# Altium schematic sheet Driver Morpheus PONTE H.SchDoc("Driver Morpheus PONTE H")
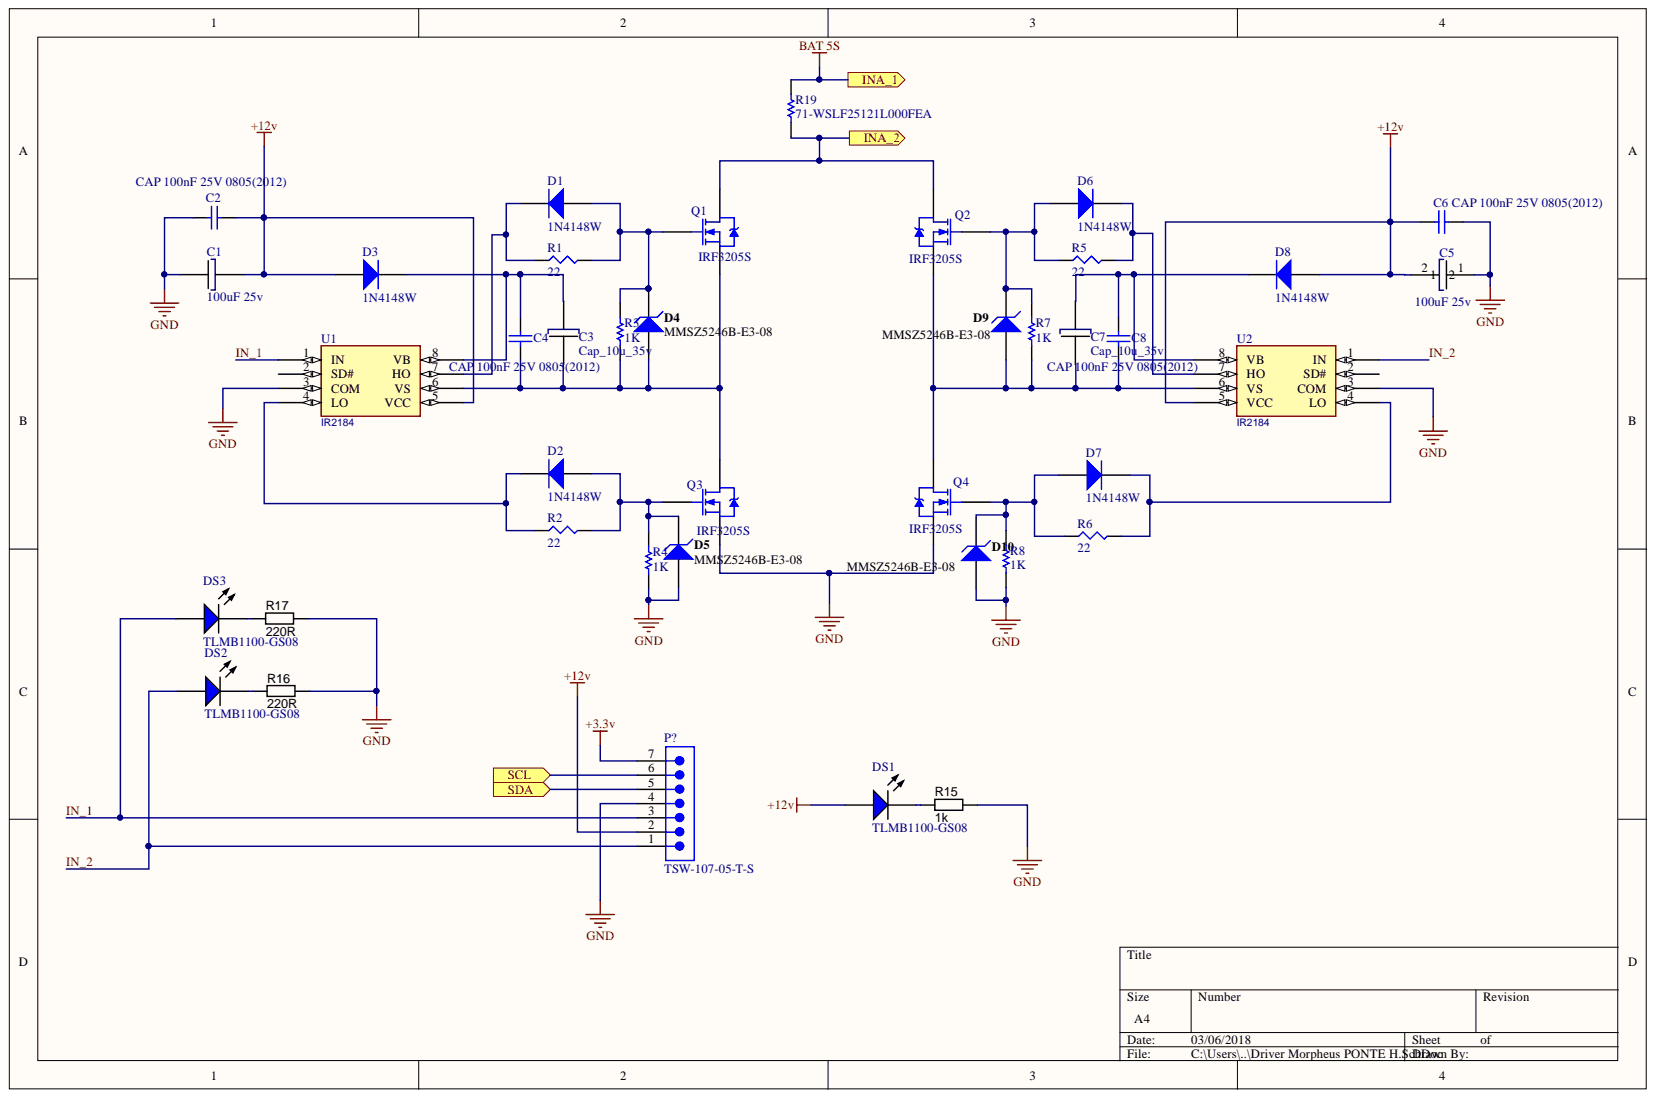
[diagram p0: Driver Morpheus PONTE H.SchDoc]

## Parts
C1  100uF 25v  [CAPAE9083X70M]
C2  CAP 100nF 25V 0805(2012)  value 100nF  [CAPC0805(2012)145_M]
C3  Cap_10u_35v  [CAP POL 2917/7343]
C4  CAP 100nF 25V 0805(2012)  value 100nF  [CAPC0805(2012)145_M]
C5  100uF 25v  [CAPAE9083X70M]
C6  CAP 100nF 25V 0805(2012)  value 100nF  [CAPC0805(2012)145_M]
C7  Cap_10u_35v  [CAP POL 2917/7343]
C8  CAP 100nF 25V 0805(2012)  value 100nF  [CAPC0805(2012)145_M]
D1  1N4148W  [JSCJ-SOD-123-2_V]
D2  1N4148W  [JSCJ-SOD-123-2_V]
D3  1N4148W  [JSCJ-SOD-123-2_V]
D4  MMSZ5246B-E3-08  [VISH-SOD-123-2_V]
D5  MMSZ5246B-E3-08  [VISH-SOD-123-2_V]
D6  1N4148W  [JSCJ-SOD-123-2_V]
D7  1N4148W  [JSCJ-SOD-123-2_V]
D8  1N4148W  [JSCJ-SOD-123-2_V]
D9  MMSZ5246B-E3-08  [VISH-SOD-123-2_V]
D10  MMSZ5246B-E3-08  [VISH-SOD-123-2_V]
DS1  TLMB1100-GS08  [VISH-TLMX1100-2_V]
DS2  TLMB1100-GS08  [VISH-TLMX1100-2_V]
DS3  TLMB1100-GS08  [VISH-TLMX1100-2_V]
P?  TSW-107-05-T-S  [SMTC-TSW-107-05-X-S]
Q1  IRF3205S  [D2PAK_N]
Q2  IRF3205S  [D2PAK_N]
Q3  IRF3205S  [D2PAK_N]
Q4  IRF3205S  [D2PAK_N]
R1  22  [SMD-0603-RES]
R2  22  [SMD-0603-RES]
R3  value 1K  [RES-0402]  (hidden comment: RC0402JR-071KL)
R4  value 1K  [RES-0402]  (hidden comment: RC0402JR-071KL)
R5  22  [SMD-0603-RES]
R6  22  [SMD-0603-RES]
R7  value 1K  [RES-0402]  (hidden comment: RC0402JR-071KL)
R8  value 1K  [RES-0402]  (hidden comment: RC0402JR-071KL)
R15  value 1k  [RESC1608X55N]  (hidden comment: Thick Film)
R16  value 220R  [RESC1608X55N]  (hidden comment: Thick Film)
R17  value 220R  [RESC1608X55N]  (hidden comment: Thick Film)
R19  71-WSLF25121L000FEA  [RESC6432X89X76ML25T25]
U1  IR2184
U2  IR2184

## Ports
INA_1, INA_2, SCL, SDA

## Nets
+3.3v: P?.7
+12v: C1.2 C2.2 C5.2 C6.2 D3.1 D8.1 DS1.1 P?.2 U1.5(VCC) U2.5(VCC)
GND: C1.1 C2.1 C5.1 C6.1 D5.1 D10.1 P?.4 Q3.3 Q4.3 R4.1 R8.1 R15.2 R16.2 R17.2 U1.3(COM) U2.3(COM)
BAT 5S: R19.2 ; port INA_1
IN_1: DS3.1 P?.3 U1.1(IN)
IN_2: DS2.1 P?.1 U2.1(IN)
INA_2: Q1.2 Q2.2 R19.1 ; port INA_2
SCL: P?.6 ; port SCL
SDA: P?.5 ; port SDA
NetC3_1: C3.1 C4.1 D4.1 Q1.3 Q3.2 R3.1 U1.6(VS)
NetC3_2: C3.2 C4.2 D3.2 U1.8(VB)
NetC7_1: C7.1 C8.1 D9.1 Q2.3 Q4.2 R7.1 U2.6(VS)
NetC7_2: C7.2 C8.2 D8.2 U2.8(VB)
NetD1_1: D1.1 D4.2 Q1.1 R1.2 R3.2
NetD1_2: D1.2 R1.1 U1.7(HO)
NetD2_1: D2.1 D5.2 Q3.1 R2.2 R4.2
NetD2_2: D2.2 R2.1 U1.4(LO)
NetD6_1: D6.1 D9.2 Q2.1 R5.1 R7.2
NetD6_2: D6.2 R5.2 U2.7(HO)
NetD7_1: D7.1 D10.2 Q4.1 R6.1 R8.2
NetD7_2: D7.2 R6.2 U2.4(LO)
NetDS1_2: DS1.2 R15.1
NetDS2_2: DS2.2 R16.1
NetDS3_2: DS3.2 R17.1

Not connected: U1 2(SD#); U2 2(SD#)

## Library data of the parts
C1 = Cap_10u_35v: Component Kind: Standard; Library Name: LibSanhaço2017.SchLib
C2 C4 C6 C8 = CMP-1036-04408-1: CAP 100nF 25V ±5% 0805 (2012 Metric) Thickness 1.45mm SMD; Tolerance: Â±5%; Case-Metric: 2012; Case-EIA: 0805; Technology: SMT; Rated Voltage: 25 V; Max Thickness: 1.45 mm; Library Name: Altium Content Vault; Component Kind: Standard
C5 = Cap_100u_25v: Cap Tântalo; Component Kind: Standard; Library Name: LibSanhaço2017.SchLib
D1-D3 D6-D8 = CMP-0914-00001-1: Fast Switching Diode, 75 V, 150 mA, 2-Pin 2.7 x 1.6 x 1.25 mm SMD, Tape and Reel; Manufacturer: Changjiang Electronics; VR (V): 75; VF (V): 1.25; TSTG (degC): -55 to 150; Trr (ns): 4; PD (mW): 350; IR (uA): 1; IO (mA): 150; PartNumber: 1N4148W; Packing: Tape and Reel; PackageVersion: Rev. A; PackageReference: SOD-123-2; PackageDescription: 2-Pin SMD, Body 2.7 x 1.6 mm, Height 1.25 mm; Mounting Technology: Surface Mount; Library Name: Altium Content Vault; DatasheetVersion: Rev. A; ComponentLink3URL: http://www.cj-elec.com/txUpfile/201412112231195145.pdf; ComponentLink3Description: Package Specification; ComponentLink2URL: http://www.cj-elec.com/txUpfile/20121613352740313.pdf; ComponentLink2Description: Datasheet; ComponentLink1URL: http://www.cj-elec.com/; ComponentLink1Description: Manufacturer URL; Component Kind: Standard
D4 D5 D9 D10 = CMP-0931-00032-1: Small Signal Zener Diode, 16 V +/- 5%, -55 to 155 degC, 2-Pin SOD-123, RoHS, Tape and Reel; Manufacturer: Vishay Semiconductor; Zener Voltage (NOM.) (V): 16; Zener Impedance (IZT1) (Max.) (Ohm): 17; Tolerance: +/- 5%; Test Current (IZT1) (mA): 7.8; Power Dissipation (mW): 500; Int. Construction: Single; RoHS: TRUE; PartNumber: MMSZ5246B-E3-08; Packing: Tape and Reel; PackageVersion: Rev. 1.9; PackageReference: SOD-123-2; PackageDescription: 2-Pin SMD, Body 2.7 x 1.55 mm, Height 1.35 mm; Operating Temperature: -55 to 150 degC; Mounting Technology: Surface Mount; Library Name: Altium Content Vault; DatasheetVersion: Rev. 1.9; ComponentLink3URL: http://www.vishay.com/docs/85774/mmsz5225.pdf; ComponentLink3Description: Package Specification; ComponentLink2URL: http://www.vishay.com/docs/85774/mmsz5225.pdf; ComponentLink2Description: Datasheet; ComponentLink1URL: http://www.vishay.com/; ComponentLink1Description: Manufacturer URL; Component Kind: Standard
DS1-DS3 = CMP-1666-00028-1: Blue LED, 10 mA, 3.9 V, -40 to 100 degC, 2-Pin SMD (0603), RoHS, Tape and Reel; Voltage Rating: 100 V; Type (Capacitors): X7R; Tolerance: 10%; Case\Package: Radial; Capacitance: 10 nF; Width: 3.81 mm; RoHSCompliant: true; REACH SVHC: No SVHC; Radiation Hardening: No; Pins: 2; Packaging: Bulk; PackageReference: 0603; Package Quantity: 500; PackageDescription: 2-Pin Surface Mount Device, Body 1.6 x 0.8 mm; Mount: Through Hole; Min Operating Temperature: -55 degC; Max Operating Temperature: 125 degC; Library Name: Altium Content Vault; Length: 5.33 mm; Lead Pitch: 2.54 mm; Height: 2.54 mm; DatasheetVersion: Rev. 2.7, 03/2016; ComponentLink2URL: http://download.siliconexpert.com/pdfs/2016/8/7/12/43/26/667/vsh_/manual/379263299541529tlmb1100.pdf; ComponentLink2Description: Datasheet; ComponentLink1URL: http://www.vishay.com/; ComponentLink1Description: Manufacturer URL; Component Kind: Standard
P? = CMP-1024-00399-1: Manufacturer: Samtec; Pitch: 2.54 mm; Temperature Range: -55 to 105 degC; RoHS: True; PartNumber: TSW-107-05-T-S; PackageVersion: DM, 8/1988; PackageReference: TSW-107-05-X-S; PackageDescription: .025[0.64] SQ. Post Terminal Strip Assembly; Orientation: Vertical; Mounting Technology: Through-hole; Library Name: Altium Content Vault; DatasheetVersion: F-214; ComponentLink3URL: http://www.samtec.com/documents/webfiles/cpdf/TSW-XXX-XX-XXX-X-XX-XXX-MKT.pdf; ComponentLink3Description: Package Specification; ComponentLink2URL: http://www.samtec.com/documents/webfiles/pdf/TSW_TH.PDF; ComponentLink2Description: Datasheet; ComponentLink1URL: http://www.samtec.com; ComponentLink1Description: Manufacturer URL; Component Kind: Standard
Q1-Q4 = IRF3205S: HEXFET Power MOSFET; Simulation: IRF3205S; Published: 8-Jun-2000; PackageReference: D2PAK; PackageDescription: 3-Pin Transistor Outline Package 2.54 mm Pitch, 15.1 mm Lead Span; Library Name: IR Discrete MOSFET - Power.IntLib; LatestRevisionNote: IPC-7351 Footprint Added.; LatestRevisionDate: 20-Nov-2009; DatasheetVersion: Aug-1997; ComponentLink2URL: http://www.irf.com/product-info/datasheets/data/irf3205s.pdf; ComponentLink2Description: Datasheet; ComponentLink1URL: http://www.irf.com/; ComponentLink1Description: Manufacturer Link; Component Kind: Standard; Publisher: Altium Limited
R1 R2 R5 R6 = ERJ-3EKF22R0V: RES SMD 22 OHM 1% 1/10W 0603; Manufacturer: Panasonic Electronic Components; Unit Price (USD): 0.00379; Temperature Coefficient: ±100ppm/°C; Power (Watts): 0.1W, 1/10W; Minimum Quantity: 1; Factory Stock: 0; @ qty: 1500; Value: 22; Tolerance: ±1%; Supplier Device Package: 0603; Size / Dimension: 0.063" L x 0.031" W (1.60mm x 0.80mm); Series: ERJ; Quantity Available: 100321; Part Status: Active; Part Number: ERJ-3EKF22R0V; Packaging: Cut Tape (CT); Package / Case: 0603 (1608 Metric); Operating Temperature: -55°C ~ 155°C; Number of Terminations: 2; Library Name: Panasonic_ERJ_0603.IntLib; Image: //media.digikey.com/Photos/Panasonic%20Photos/MFG_ERJ-P6W%20Series.jpg; Height - Seated (Max): 0.022" (0.55mm); Features: Automotive AEC-Q200; Failure Rate: -; Digi-Key Part Number: P22.0HCT-ND; Datasheets: http://industrial.panasonic.com/www-cgi/jvcr13pz.cgi?E+PZ+3+AOA0002+ERJ3EKF22R0V+7+WW; Composition: Thick Film; Component Kind: Standard
R3 R4 R7 R8 = RES 1K 0402: RES SMD 1K OHM 5% 1/16W 0402; Simulation: Model Name; Library Name: Schlib1.SchLib; Component Kind: Standard
R15-R17 = RES_220R_0603_5%: Resistor SMD chip 220 Ohm 0.1W 5% 0603; Manufacturer Part Number 1: RC0603JR-07220RL; Manufacturer 1: Yageo; SCDType: spec; Ratings: 1/10 W; Tolerance: ±5%; Supplier Part Number 4: RHM220CGCT-ND; Supplier Part Number 3: RMCF0603JT220RCT-ND; Supplier Part Number 2: P220GCT-ND; Supplier Part Number 1: 311-220GRCT-ND; Supplier 4: Digi-Key; Supplier 3: Digi-Key; Supplier 2: Digi-Key; Supplier 1: Digi-Key; RoHS 4: RoHS Compliant; RoHS 3: RoHS Compliant; RoHS 2: RoHS Compliant; RoHS 1: RoHS Compliant; Package / Case: 0603 (1608 metric); Material: Thick Film; Manufacturer Part Number 5: 0603WAJ0221; Manufacturer Part Number 4: MCR03ERTJ221; Manufacturer Part Number 3: RMCF0603JT220R; Manufacturer Part Number 2: ERJ-3GEYJ221V; Manufacturer 5: Royal Ohm; Manufacturer 4: Rohm Semiconductor; Manufacturer 3: Stackpole Electronics Inc; Manufacturer 2: Panasonic Electronic Components; Library Name: PBL - Resistors.SCHLIB; ComponentLink4URL: http://rohmfs.rohm.com/en/products/databook/datasheet/passive/resistor/chip_resistor/mcr_g.pdf; ComponentLink4Description: http://rohmfs.rohm.com/en/products/databook/datasheet/passive/resistor/chip_resistor/mcr_g.pdf; ComponentLink3URL: http://www.seielect.com/Catalog/SEI-RMCF_RMCP.pdf; ComponentLink3Description: http://www.seielect.com/Catalog/SEI-RMCF_RMCP.pdf; ComponentLink2URL: http://industrial.panasonic.com/www-cgi/jvcr13pz.cgi?E+PZ+3+AOA0001+ERJ3GEYJ221V+7+WW; ComponentLink2Description: http://industrial.panasonic.com/www-cgi/jvcr13pz.cgi?E+PZ+3+AOA0001+ERJ3GEYJ221V+7+WW; ComponentLink1URL: http://www.yageo.com/documents/recent/PYu-RC0603_51_RoHS_L_4.pdf; ComponentLink1Description: http://www.yageo.com/documents/recent/PYu-RC0603_51_RoHS_L_4.pdf; Component Kind: Standard
R19 = CMP-2000-07419-1: Chip Resistor, 9 mOhm, +/- 1%, 1 W, -65 to 170 degC, 2512 (6432 Metric), RoHS, Tape and Reel                        71-WSLF25121L000FEA; Manufacturer: Vishay; Temperature Coefficient: 75PPM/C; Resistance: 0.009Ohms; Power Rating: 6W; Case Width: 3.2mm; Case Length: 6.4mm; Case Height: 0.635mm; Brand: Vishay/Dale; Type: PowerMetalStripResistors,LowValue(downto0.0005Ohms),SurfaceMount; Tolerance: 1%; Termination Style: SMD/SMT; Series: WSL; RoHS: Details; Product Category: CurrentSenseResistors-SMD; Product: MetalElementCurrentSensingResistors; Packaging: Reel; Package Reference: 2512; Package Description: 2-Pin Surface Mount Device, Body 6.35 x 3.18 mm; Package / Case: 2512(6432metric); Operating Temperature Range: -65Cto+170C; Mounting Technology: SurfaceMount; Manufacturer URL: http://www.vishay.com/; Library Name: Resistor 2512 1m.IntLib; Factory Pack Quantity: 2000; Datasheet Version: 07/2016; Datasheet URL: http://www.vishay.com/docs/30100/wsl.pdf; Component Kind: Standard; Case Code - mm: 6432; Case Code - in: 2512
U1 U2 = IR2184: V3: 20; V2: 15; V1: 10; TONV3: 210N; TOFFV3: 270N; T3: 125; T2: 25; T1: -40; RDELAY: 50; PSpiceTemplate: X^@REFDES %VCC %IN %SD %COM %VB %HO %VS %LO @MODEL PARAMS:  ?CDELAY|CDELAY=@CDELAY||CDELAY=50N| ?RDELAY|RDELAY=@RDELAY||RDELAY=50| ?T1|T1=@T1||T1=-40| ?T2|T2=@T2||T2=25| ?T3|T3=@T3||T3=125| ?V1|V1=@V1||V1=10| ?V2|V2=@V2||V2=15| ?V3|V3=@V3||V3=20| ?TOFFT1|TOFFT1=@TOFFT1||TOFFT1=270N| ?TOFFT2|TOFFT2=@TOFFT2||TOFFT2=270N| ?TOFFT3|TOFFT3=@TOFFT3||TOFFT3=270N| ?TOFFV1|TOFFV1=@TOFFV1||TOFFV1=270N| ?TOFFV2|TOFFV2=@TOFFV2||TOFFV2=270N| ?TOFFV3|TOFFV3=@TOFFV3||TOFFV3=270N| ?TONT1|TONT1=@TONT1||TONT1=210N| ?TONT2|TONT2=@TONT2||TONT2=210N| ?TONT3|TONT3=@TONT3||TONT3=210N| ?TONV1|TONV1=@TONV1||TONV1=210N| ?TONV2|TONV2=@TONV2||TONV2=210N| ?TONV3|TONV3=@TONV3||TONV3=210N|; CDELAY: 50N; TONV2: 210N; TONV1: 210N; TONT3: 210N; TONT2: 210N; TONT1: 210N; TOFFV2: 270N; TOFFV1: 270N; TOFFT3: 270N; TOFFT2: 270N; TOFFT1: 270N; Simulation: IR2184; Library Name: IR2184_Library.SchLib; Component Kind: Standard
C3 C7 = Cap_10u_35v: Component Kind: Standard; Library Name: LibSanhaço2017.SchLib
